# Altium schematic sheet CW553_LCD.SchDoc
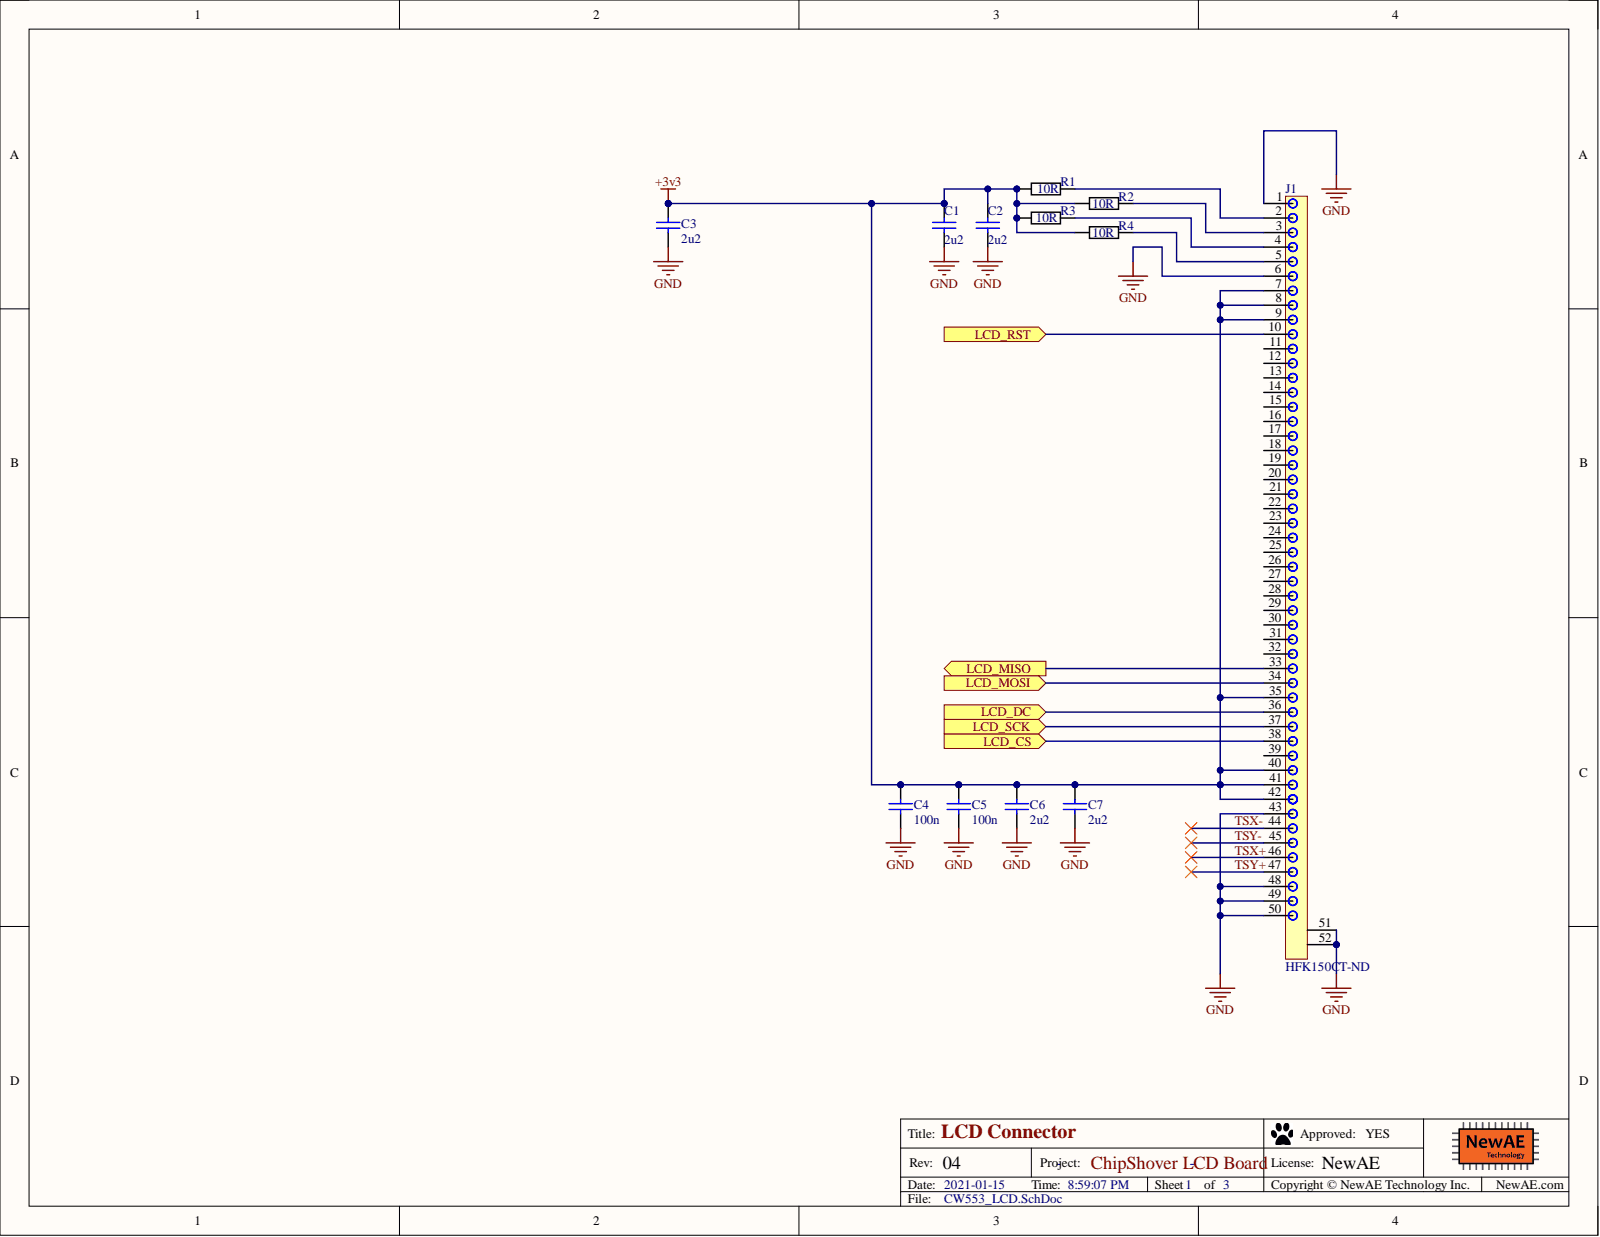
[diagram p0: CW553_LCD.SchDoc]

## Parts
C1  2u2  [0603]
C2  2u2  [0603]
C3  2u2  [0603]
C4  100n  [0603]
C5  100n  [0603]
C6  2u2  [0603]
C7  2u2  [0603]
J1  HFK150CT-ND  [MI0283QT-11-1.6mmthickness]
R1  10R  [0603]
R2  10R  [0603]
R3  10R  [0603]
R4  10R  [0603]

## Ports
LCD_CS, LCD_DC, LCD_MISO, LCD_MOSI, LCD_RST, LCD_SCK

## Nets
+3v3: C1.1 C2.1 C3.1 C4.1 C5.1 C6.1 C7.1 J1.7 J1.8 J1.9 J1.35 J1.40 J1.41 J1.42 R1.1 R2.1 R3.1 R4.1
GND: C1.2 C2.2 C3.2 C4.2 C5.2 C6.2 C7.2 J1.1 J1.6 J1.43 J1.48 J1.49 J1.50 J1.51 J1.52
LCD_CS: J1.38 ; port LCD_CS
LCD_DC: J1.36 ; port LCD_DC
LCD_MISO: J1.33 ; port LCD_MISO
LCD_MOSI: J1.34 ; port LCD_MOSI
LCD_RST: J1.10 ; port LCD_RST
LCD_SCK: J1.37 ; port LCD_SCK
TSX+: J1.46
TSX-: J1.44
TSY+: J1.47
TSY-: J1.45
NetJ1_2: J1.2 R1.2
NetJ1_3: J1.3 R2.2
NetJ1_4: J1.4 R3.2
NetJ1_5: J1.5 R4.2

Not connected: J1 11 12 13 14 15 16 17 18 19 20 21 22 23 24 25 26 27 28 29 30 31 32 39

## Library data of the parts
C1-C3 C6 C7 = CCHIP-2u2-0603: 2u2, 0603; Case-Metric: 1608; Component Group: Capacitor; Database Table Name: main; Case-EIA: 0603; Component Kind: Standard; Component Type: Standard; Library Name: NewAE_AltiumLib.DBLib; Mounting Technology: SMT; Part Number: CCHIP-2u2-0603; Pin Count: 2; Value: 2u2
C4 C5 = CCHIP-100n-0603: 100n, 0603; Case-Metric: 1608; Component Group: Capacitor; Database Table Name: main; Case-EIA: 0603; Component Kind: Standard; Component Type: Standard; Library Name: NewAE_AltiumLib.DBLib; Mounting Technology: SMT; Part Number: CCHIP-100n-0603; Pin Count: 2; Value: 100n
J1 = MI0283QT-11-1mmOFFSET: LCD, TFT, Touchscreen, 2.8"; Manufacture 1: Multi-Inno; Manufacture Part Number 1: MI0283QT-11 + FH12A-30S-0.5SH(55); Component Group: Optical; Database Table Name: main; PP Material Stack: 0; Component Kind: Standard; Component Type: Standard; Library Name: NewAE_AltiumLib.DBLib; Part Number: MI0283QT-11-1mmOFFSET; Pin Count: 52; PP Rotation: 0; Supplier 1: Digi-Key
R1-R4 = RCHIP-10R-0603: 10R res, 0603, 1%, 1/10W; Manufacture 1: Yageo; Manufacture Part Number 1: RC0603FR-0710RL; Case-Metric: 1608; Component Group: Resistor; Database Table Name: main; Rated Power: 0.1W; Rated Voltage: 75VDC; Case-EIA: 0603; Component Kind: Standard; Component Type: Standard; Library Name: NewAE_AltiumLib.DBLib; Mounting Technology: SMT; Part Number: RCHIP-10R-0603; Pin Count: 2; Supplier 1: Digi-Key; Supplier Part Number 1: 311-10.0HRCT-ND; Temperature Range: -55C to 155C; Tolerance: 1%; Value: 10R
